# Altium schematic sheet MCU.SchDoc
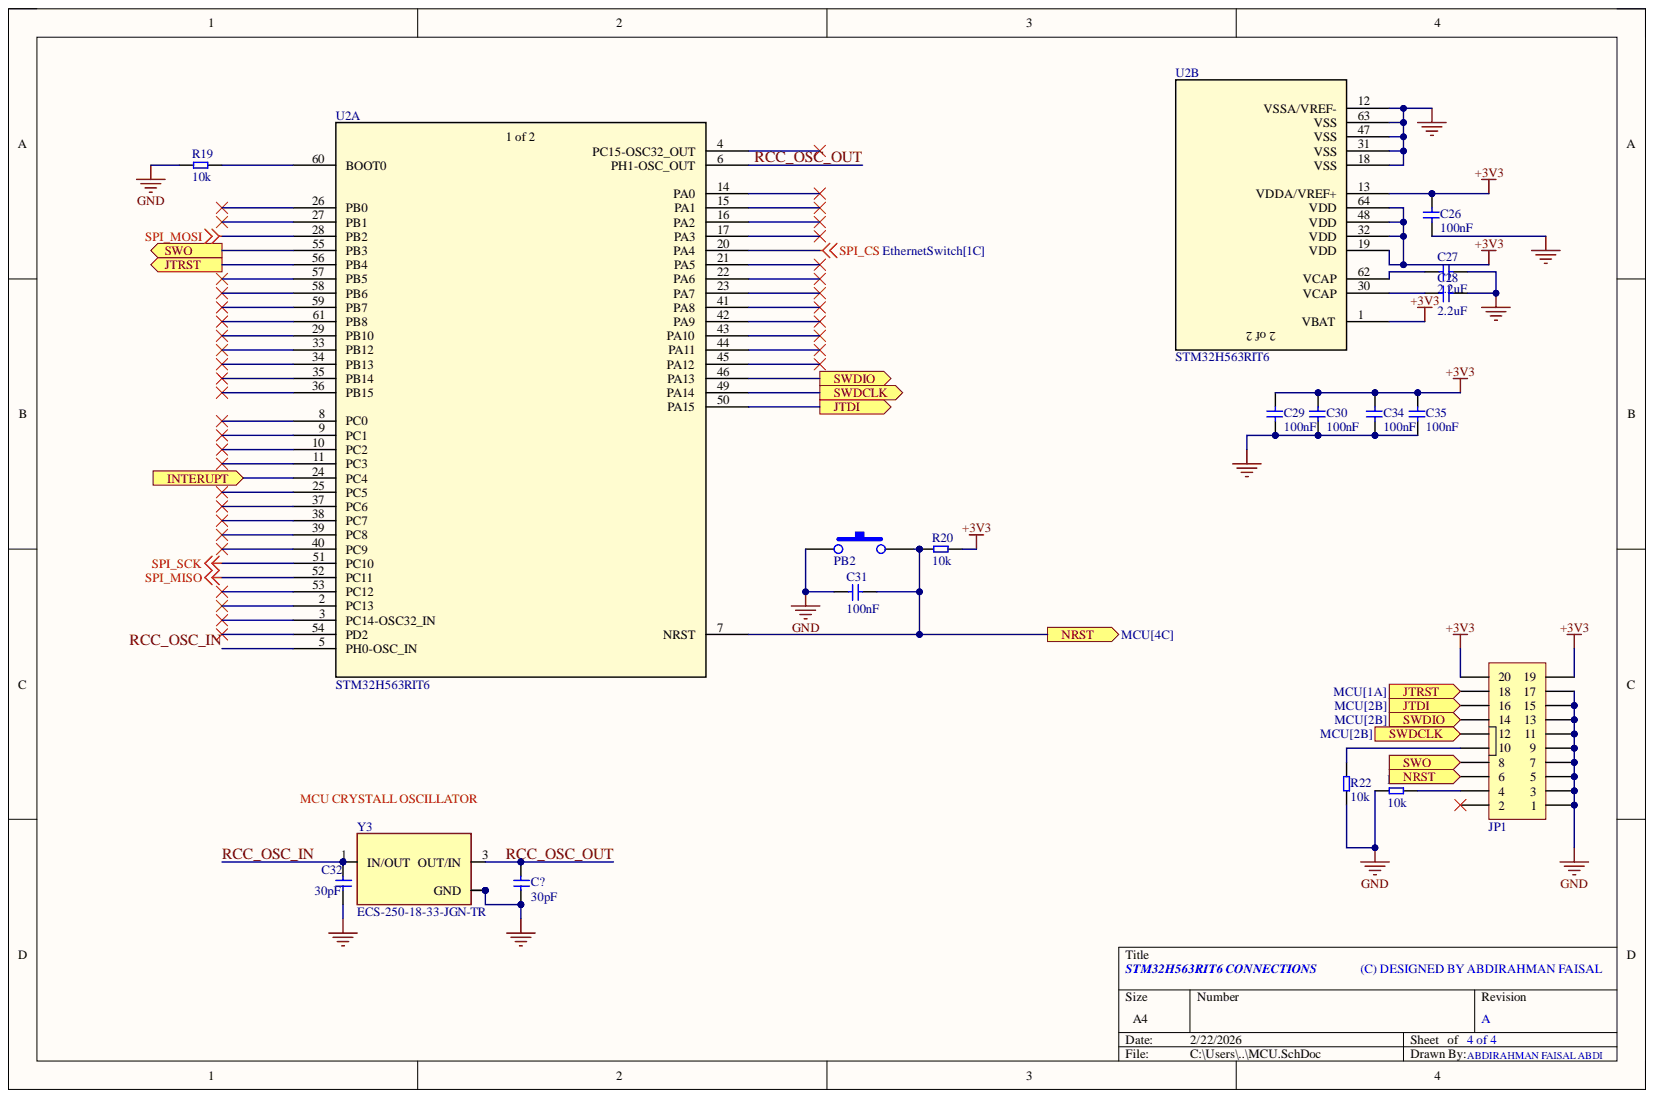
[diagram p0: MCU.SchDoc]

## Parts
C26  100nF  [C0603]
C27  2.2uF  [C0603]
C28  2.2uF  [C0603]
C29  100nF  [C0603]
C30  100nF  [C0603]
C31  100nF  [C0603]
C32  30pF  [C0603]
C34  100nF  [C0603]
C35  100nF  [C0603]
C?  30pF  [C0603]
JP1  Box_Header 2X10  [Box_Header 2x10]  (value not drawn)
PB2  PUSH_BUTTON  [Push Button SPST]  (value not drawn)
R19  10k  [R0603]
R20  10k  [R0603]
R21  10k  [R0603]
R22  10k  [R0603]
U2  STM32H563RIT6  [LQFP64-10x10mm_STM32H563RIT6]  (parts A,B)
Y3  ECS-250-18-33-JGN-TR  [XTAL_ECS-250-18-33-JGN-TR]

## Ports
INTERUPT, JTDI, JTRST, NRST, SWDCLK, SWDIO, SWO

## Nets
+3V3: C26.1 C29.1 C30.1 C34.1 C35.1 JP1.19 JP1.20 R20.2 U2.1(VBAT) U2.13(VDDA/VREF+) U2.19(VDD) U2.32(VDD) U2.48(VDD) U2.64(VDD)
GND: C26.2 C27.2 C28.2 C29.2 C30.2 C31.1 C32.1 C34.2 C35.2 C?.1 JP1.1 JP1.3 JP1.5 JP1.7 JP1.9 JP1.11 JP1.13 JP1.15 JP1.17 PB2.1 R19.1 R21.1 R22.1 U2.12(VSSA/VREF-) U2.18(VSS) U2.31(VSS) U2.47(VSS) U2.63(VSS) Y3.2(GND) Y3.4
SPI_CS: U2.20(PA4)
SPI_MISO: U2.52(PC11)
SPI_MOSI: U2.28(PB2)
SPI_SCK: U2.51(PC10)
INTERUPT: U2.24(PC4) ; port INTERUPT
JTDI: JP1.16 U2.50(PA15) ; port JTDI
JTRST: JP1.18 U2.56(PB4) ; port JTRST
NRST: C31.2 JP1.6 PB2.2 R20.1 U2.7(NRST) ; port NRST
RCC_OSC_IN: C32.2 U2.5(PH0-OSC_IN) Y3.1(IN/OUT)
RCC_OSC_OUT: C?.2 U2.6(PH1-OSC_OUT) Y3.3(OUT/IN)
SWDCLK: JP1.12 U2.49(PA14) ; port SWDCLK
SWDIO: JP1.14 U2.46(PA13) ; port SWDIO
SWO: JP1.8 U2.55(PB3) ; port SWO
NetC27_1: C27.1 U2.62(VCAP)
NetC28_1: C28.1 U2.30(VCAP)
NetJP1_4: JP1.4 R21.2
NetJP1_10: JP1.10 R22.2
NetR19_2: R19.2 U2.60(BOOT0)

Not connected: JP1 2; U2 2(PC13) 3(PC14-OSC32_IN) 4(PC15-OSC32_OUT) 8(PC0) 9(PC1) 10(PC2) 11(PC3) 14(PA0) 15(PA1) 16(PA2) 17(PA3) 21(PA5) 22(PA6) 23(PA7) 25(PC5) 26(PB0) 27(PB1) 29(PB10) 33(PB12) 34(PB13) 35(PB14) 36(PB15) 37(PC6) 38(PC7) 39(PC8) 40(PC9) 41(PA8) 42(PA9) 43(PA10) 44(PA11) 45(PA12) 53(PC12) 54(PD2) 57(PB5) 58(PB6) 59(PB7) 61(PB8)

## Library data of the parts
C26 C29-C31 C34 C35 = CGA3E3X8R1H104K080AD: CAP CER 0.1UF 50V X8R 0603 EPOXY; Manufacturer: TDK; Category: Ceramic Capacitors; Component Kind: Standard; ComponentLink1Description: https://datasheet.ciiva.com/24219/cga3e3x8r1h104k080ad-270768-24219691.pdf?src-supplier=Mouser; ComponentLink1URL: https://datasheet.ciiva.com/24219/cga3e3x8r1h104k080ad-270768-24219691.pdf?src-supplier=Mouser; LCSC part number: C2171928; Manufacturer Part Number: CGA3E3X8R1H104K080AD; Pricing 1: 1=0.22, 10=0.129, 50=0.0922, 100=0.0693, 500=0.06232, 1000=0.05656 (USD); RoHS: Yes; Stock 1: 30979; Supplier 1: Digikey
  C26 C29 C30 C34 C35: Library Name: IO.SchLib
  C31: Library Name: arfaisal.IntLib
C27 C28 = CC0603KRX7R6BB225: Multilayer Ceramic Capacitor, 2.2 uF, 10 V, ± 10%, X7R, 0603 [1608 Metric]; Manufacturer: Yageo; Material: 4241ConductorMaterial; Voltage Rating: 10V; Capacitance: 2.2uF; Case Code (Imperial): 0603; Case Code (Metric): 1608; Case/Package: 0603; Category: Ceramic Capacitors; Component Kind: Standard; ComponentLink1Description: https://datasheet.ciiva.com/13210/upy-gphc-x7r-6-3v-to-50v-8-12304-13210987.pdf?src-supplier=Mouser; ComponentLink1URL: https://datasheet.ciiva.com/13210/upy-gphc-x7r-6-3v-to-50v-8-12304-13210987.pdf?src-supplier=Mouser; Dielectric: X7R; Halogen Free: Halogen Free; LCSC part number: C513691; Lead Free: Lead Free; Length: 1.6mm; Library Name: IO.SchLib; Manufacturer Part Number: CC0603KRX7R6BB225; Max Operating Temperature: 125°C; Min Operating Temperature: -55°C; Mount: Surface Mount; Number of Pins: 2NUMBER; Packaging: Tape and Reel; Pricing 1: 1=0.14, 10=0.079, 50=0.0552, 100=0.0429, 500=0.03596, 1000=0.03223 (USD); RoHS: Yes; RoHS Compliant: Yes; Series: CC; Stock 1: 14335; Supplier 1: Digikey; Termination: SMD/SMT; Tolerance: 10%; Voltage Rating (DC): 10V; Width: 0.8mm
C32 C? = AC0603JRNPO9BN300: CAP CER 30PF 50V C0G/NPO 0603; Manufacturer: Yageo; Category: Ceramic Capacitors; Component Kind: Standard; LCSC part number: C2191217; Library Name: IO.SchLib; Manufacturer Part Number: AC0603JRNPO9BN300; Pricing 1: 1=0.11, 10=0.061, 50=0.0424, 100=0.035, 500=0.02706, 1000=0.02316 (USD); RoHS: No; Stock 1: 2686; Supplier 1: Digikey
JP1 = Box_Header 2X10: Header, 10-Pin, Dual row; Component Kind: Standard; Published: 28-May-2008; Library Name: UTM_RBC_20241.IntLib; Publisher: UTM ROBOCON
PB2 = PB: Component Kind: Standard; Library Name: arfaisal.IntLib
R19-R22 = RT0603FRE0710KL: Fixed Resistor, Thin Film, 0.1W, 10000ohm, 75V, 1% +/-Tol, 50ppm/Cel, Surface Mount, 0603; Manufacturer: Yageo; Max Power Dissipation: 100mW; Resistance: 10kR; Temperature Coefficient: 50ppm/°C; Case Code (Imperial): 0603; Case Code (Metric): 1608; Case/Package: 0603; Category: Chip SMD Resistors; Component Kind: Standard; ComponentLink1Description: https://datasheet.ciiva.com/12694/pyu-rt-1-to-0-05-rohs-l-4-12694910.pdf?src-supplier=Mouser; ComponentLink1URL: https://datasheet.ciiva.com/12694/pyu-rt-1-to-0-05-rohs-l-4-12694910.pdf?src-supplier=Mouser; ComponentLink2Description: https://datasheet.ciiva.com/16745/pyu-rt-1-to-0-05-rohs-l-4-16745739.pdf?src-supplier=Future+Electronics; ComponentLink2URL: https://datasheet.ciiva.com/16745/pyu-rt-1-to-0-05-rohs-l-4-16745739.pdf?src-supplier=Future+Electronics; ComponentLink3Description: https://datasheet.ciiva.com/2559/pyu-rt-1-to-0-05-rohs-l-5-2559482.pdf?src-supplier=Digikey; ComponentLink3URL: https://datasheet.ciiva.com/2559/pyu-rt-1-to-0-05-rohs-l-5-2559482.pdf?src-supplier=Digikey; Contact Plating: Tin,Lead; Features: Moisture Resistant; Height: 0.022inch; LCSC part number: C469659; Lead Free: 5697LeadFree; Length: 0.063inch; Manufacturer Part Number: RT0603FRE0710KL; Max Operating Temperature: 125°C; Min Operating Temperature: -55°C; Number of Pins: 2; Number of Terminations: 2; Package Quantity: 5000; Packaging: Tape and Reel; Power Rating: 100mW; Pricing 1: 1=0.1, 10=0.012, 25=0.0116, 50=0.0112, 100=0.0107, 250=0.0102 (USD); Reach SVHC Compliant: Unknown; Resistance Tolerance: 1%; Resistor Type: Thin Film; RoHS: Yes; RoHS Compliant: Yes; Series: RT; Size Code: 0603; Stock 1: 237229; Supplier 1: Digikey; Termination: SMD/SMT; Tolerance: 1%; Voltage Rating: 75V; Voltage Rating (DC): 75V; Width: 0.031inch; Library Name: arfaisal.IntLib
U2 = STM32H563RIT6: High-performance, Arm Cortex-M33 with TrustZone, MCU with 2-MByte Flash, 640-Kbyte RAM, 250 MHz CPU; Manufacturer_Name: STMicroelectronics; Component Kind: Standard; ComponentLink1Description: New link; Datasheet: https://www.st.com/resource/en/datasheet/stm32h562ag.pdf; LCSC part number: C20619634; Manufacturer_Part_Number: STM32H563RIT6; RefDes: RefDes; Type: Type; Copyright: Copyright (C) 2025 Ultra Librarian. All rights reserved.; Library Name: arfaisal.IntLib
Y3 = ECS-250-18-33-JGN-TR (2): CRYSTAL 25.0000MHZ 18PF SMD; Manufacturer: ECS International; ESR (Equivalent Series Resistance): 40R; Frequency: 25MHz; Frequency Stability: 30ppm; Frequency Tolerance: 20ppm; Case/Package: SMD/SMT; Category: Crystals; Component Kind: Standard; ComponentLink1Description: https://www.ecsxtal.com/store/pdf/ecx-32.pdf?src-supplier=Digikey; ComponentLink1URL: https://www.ecsxtal.com/store/pdf/ecx-32.pdf?src-supplier=Digikey; ComponentLink2Description: https://datasheet.octopart.com/ECS-250-18-33-JGN-TR-ECS-International-datasheet-140089731.pdf?src-supplier=Future+Electronics; ComponentLink2URL: https://datasheet.octopart.com/ECS-250-18-33-JGN-TR-ECS-International-datasheet-140089731.pdf?src-supplier=Future+Electronics; ComponentLink3Description: https://datasheet.octopart.com/ECS-250-18-33-JGN-TR-ECS-International-datasheet-17018741.pdf?src-supplier=Electro+Sonic; ComponentLink3URL: https://datasheet.octopart.com/ECS-250-18-33-JGN-TR-ECS-International-datasheet-17018741.pdf?src-supplier=Electro+Sonic; ComponentLink4Description: https://datasheet.octopart.com/ECS-250-18-33-JGN-TR-ECS-International-datasheet-179230830.pdf?src-supplier=Master+Electronics; ComponentLink4URL: https://datasheet.octopart.com/ECS-250-18-33-JGN-TR-ECS-International-datasheet-179230830.pdf?src-supplier=Master+Electronics; Library Name: IO.SchLib; Load Capacitance: 18pF; Manufacturer Part Number: ECS-250-18-33-JGN-TR; Max Operating Temperature: 85°C; Min Operating Temperature: -40°C; Mount: Surface Mount; Packaging: Cut Tape; Pricing 1: 1=0.41, 10=0.355, 25=0.336, 50=0.3222, 100=0.3089, 250=0.29208, 500=0.28 (USD); RoHS: Yes; RoHS Compliant: Yes; Series: ECX-32; Stock 1: 39748; Supplier 1: Digikey
